# Altium schematic sheet LED&KEY&RELAY.SchDoc(LED&KEY&RELAY)
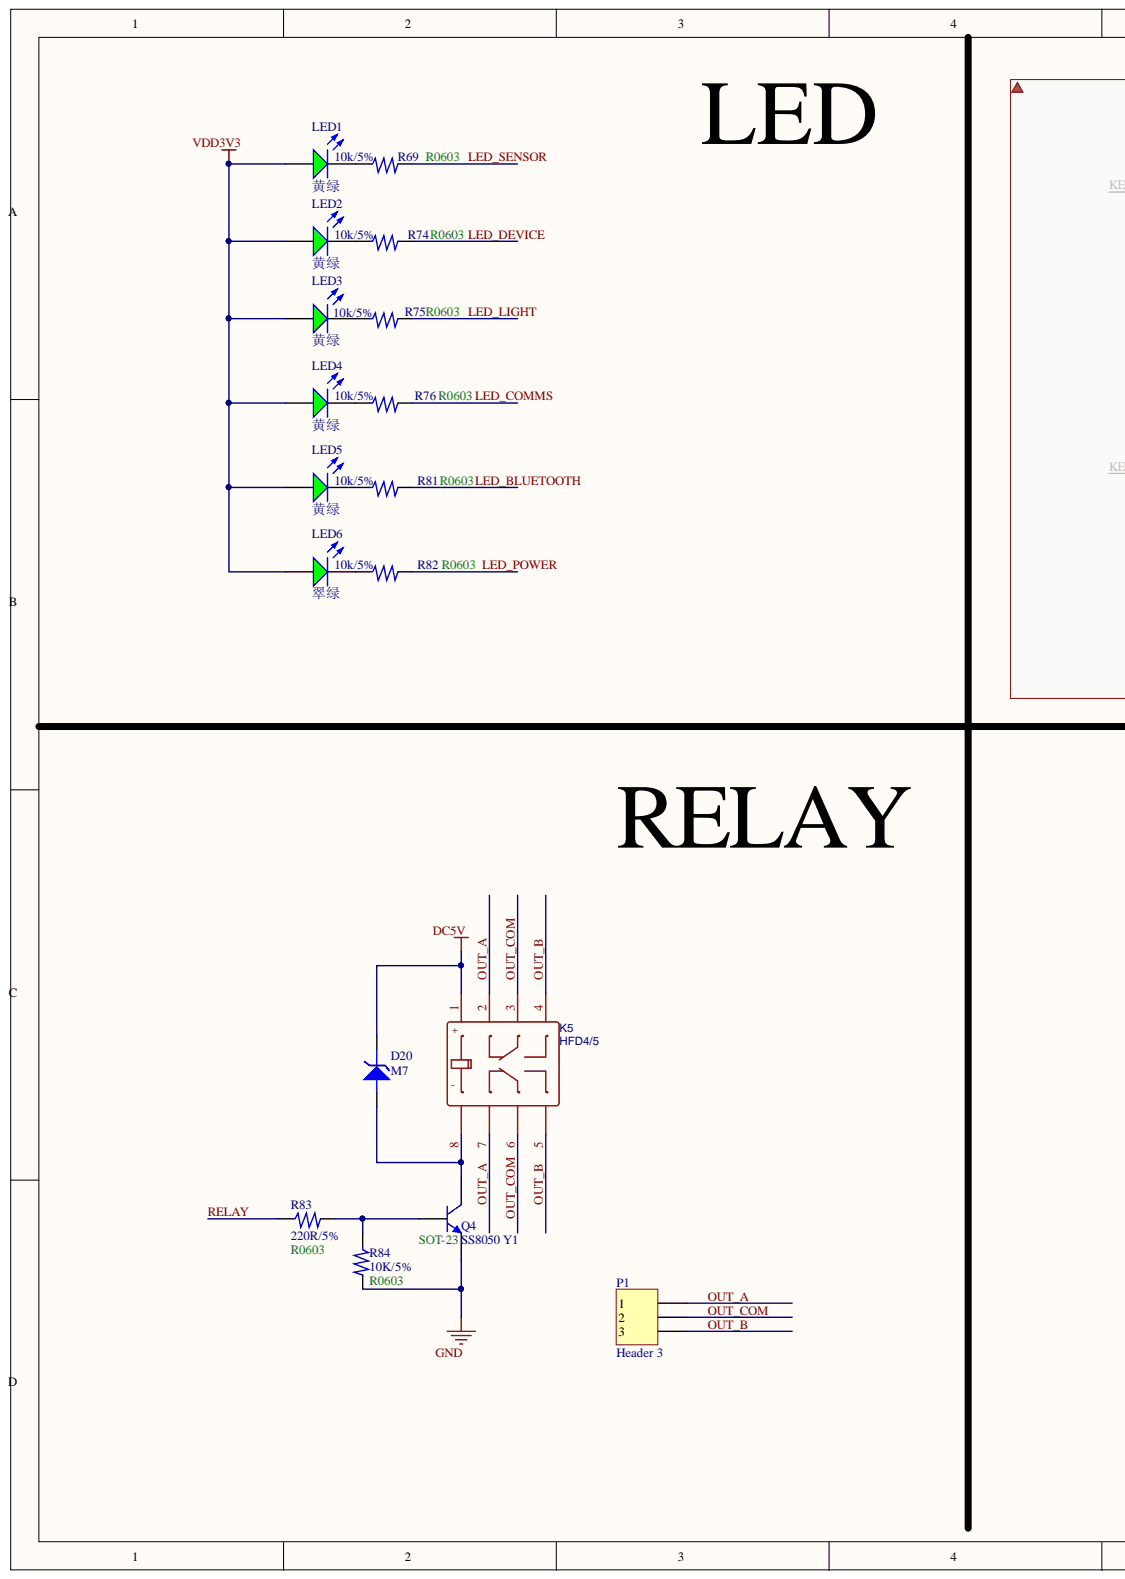
[diagram p0: LED&KEY&RELAY.SchDoc, left]
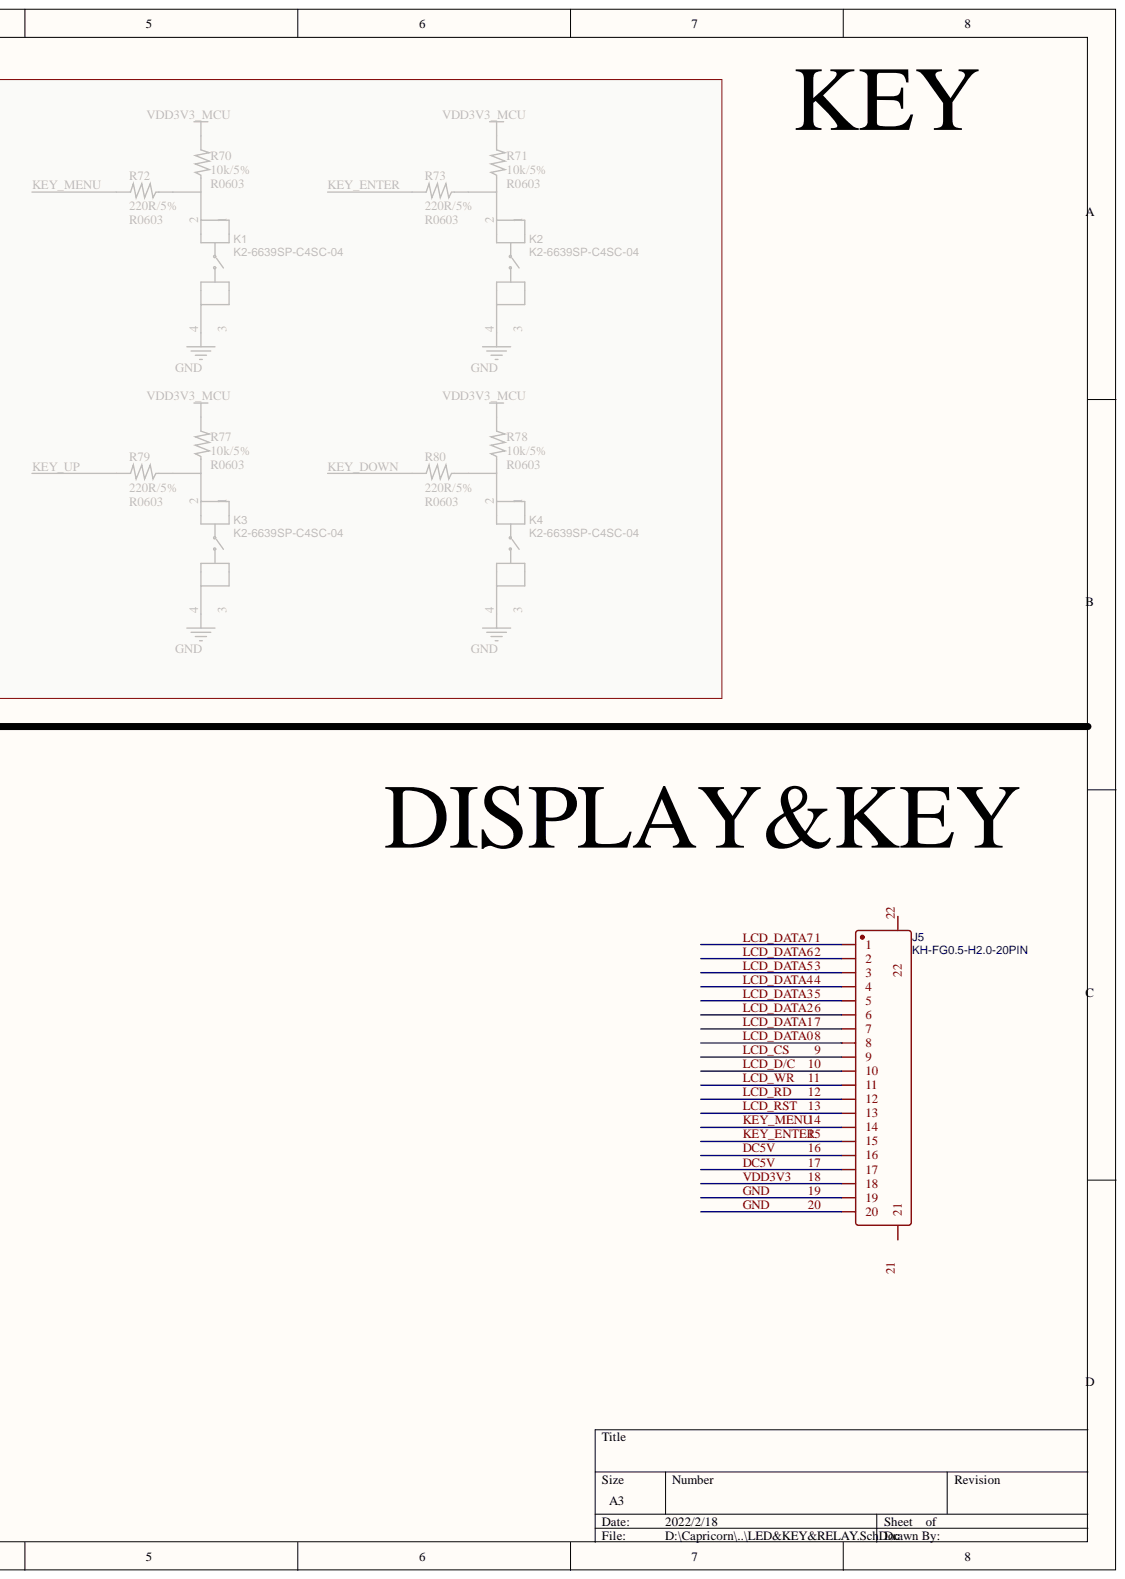
[diagram p1: LED&KEY&RELAY.SchDoc, right]

## Parts
D20  M7  [SO-0.4]
J5  KH-FG0.5-H2.0-20PIN  [FPC-SMD_KH-FG0.5-H2.0-20PIN]
K5  HFD4/5  [RELAY-TH_HFDXXX]
LED1  黄绿  [LED-3F]
LED2  黄绿  [LED-3F]
LED3  黄绿  [LED-3F]
LED4  黄绿  [LED-3F]
LED5  黄绿  [LED-3F]
LED6  翠绿  [LED-3F]
P1  Header 3  [CON-KF-22R-3P]
Q4  SS8050 Y1  Package: SOT-23  [SOT-23(SOT-23-3)]
R69  10k/5%  [R0603]
R74  10k/5%  [R0603]
R75  10k/5%  [R0603]
R76  10k/5%  [R0603]
R81  10k/5%  [R0603]
R82  10k/5%  [R0603]
R83  220R/5%  [R0603]
R84  10K/5%  [R0603]

## Nets
DC5V: D20.K J5.16 J5.17 K5.1
GND: J5.19 J5.20 Q4.2 R84.1
KEY_ENTER: J5.15
KEY_MENU: J5.14
LCD_CS: J5.9
LCD_D/C: J5.10
LCD_DATA0: J5.8
LCD_DATA1: J5.7
LCD_DATA2: J5.6
LCD_DATA3: J5.5
LCD_DATA4: J5.4
LCD_DATA5: J5.3
LCD_DATA6: J5.2
LCD_DATA7: J5.1
LCD_RD: J5.12
LCD_RST: J5.13
LCD_WR: J5.11
LED_BLUETOOTH: R81.1
LED_COMMS: R76.1
LED_DEVICE: R74.1
LED_LIGHT: R75.1
LED_POWER: R82.1
LED_SENSOR: R69.1
OUT_A: K5.2 K5.7 P1.1
OUT_B: K5.4 K5.5 P1.3
OUT_COM: K5.3 K5.6 P1.2
RELAY: R83.2
VDD3V3: J5.18 LED1.A LED2.A LED3.A LED4.A LED5.A LED6.A
NetD20_A: D20.A K5.8 Q4.3
NetLED1_K: LED1.K R69.2
NetLED2_K: LED2.K R74.2
NetLED3_K: LED3.K R75.2
NetLED4_K: LED4.K R76.2
NetLED5_K: LED5.K R81.2
NetLED6_K: LED6.K R82.2
NetQ4_1: Q4.1 R83.1 R84.2

Not connected: J5 21 22

## Library data of the parts
D20 = ZENER3: Zener Diode; Component Kind: Standard
J5 = KH-FG0.5-H2.0-20PIN: BOM_Manufacturer: kinghelm(金航标); Contributor: LCSC; BOM_Manufacturer Part: KH-FG0.5-H2.0-20PIN; BOM_Supplier: LCSC; BOM_Supplier Part: C2797211; Component Kind: Standard; spicePre: C; spiceSymbolName: KH-FG0.5-H2.0-20PIN
K5 = HFD4/5: BOM_Manufacturer: HF; BOM_JLCPCB Part Class: Extended Part; BOM_Manufacturer Part: HFD4/5; BOM_Supplier: LCSC; BOM_Supplier Part: C23510; Component Kind: Standard; spicePre: K; spiceSymbolName: HFD4/5
LED1-LED6 = 0805 翠绿: 发光二极管; Component Kind: Standard; ComponentLink1Description: 供应商链接; ComponentLink1URL: http://www.szlcsc.com/product/details_2670.html; Library Name: Miscellaneous Devices LC.IntLib; Package: 0805; Supplier: LC; SuppliersPartNumber: C2297
P1 = Header 3: Header, 3-Pin; Component Kind: Standard; LatestRevisionDate: 17-Jul-2002; LatestRevisionNote: Re-released for DXP Platform.; Library Name: Miscellaneous Connectors.IntLib; Signal Integrity: Connector; Publisher: Altium Limited
Q4 = SOT-23 SS8050 Y1: 三极管; Component Kind: Standard; ComponentLink1Description: 供应商链接; ComponentLink1URL: http://www.szlcsc.com/product/details_2507.html; Library Name: Miscellaneous Devices LC.IntLib; Supplier: LC; SuppliersPartNumber: C2150
R69 R74-R76 R81-R84 = 电阻: Component Kind: Standard
